# Altium schematic sheet 03_ATK_DLRK3506J_Power_USBHUB.SchDoc
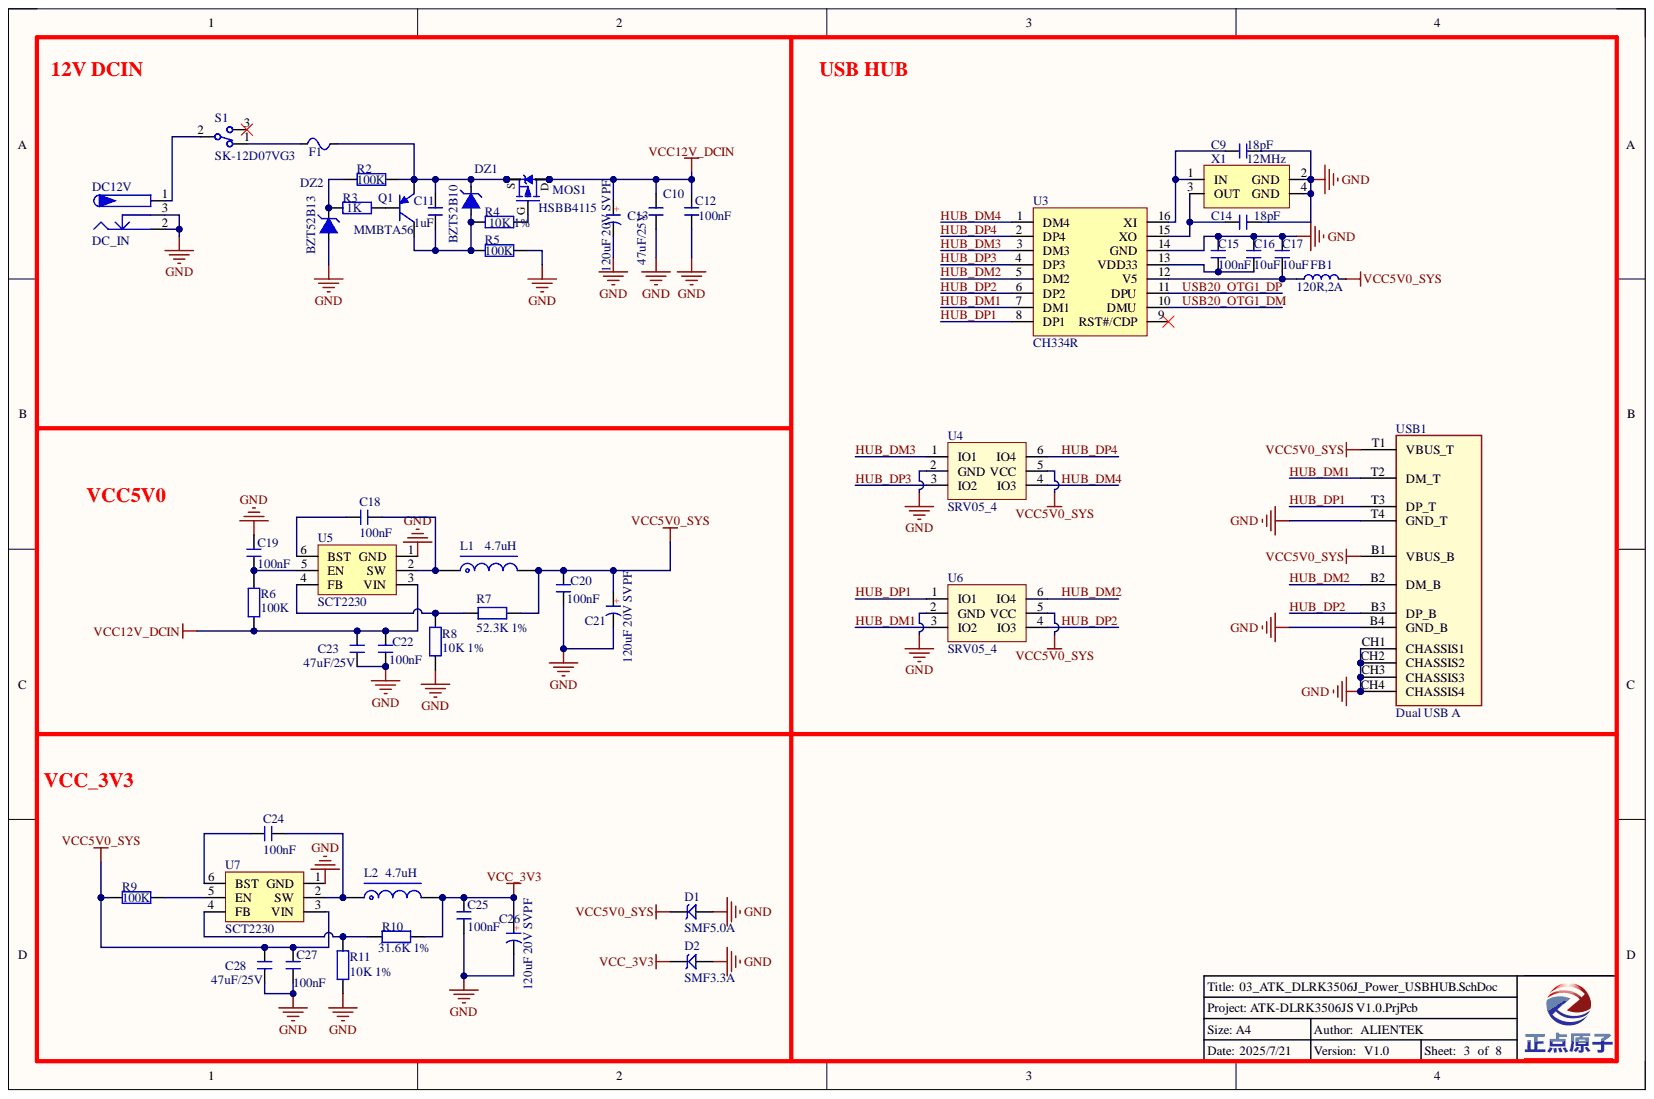
[diagram p0: 03_ATK_DLRK3506J_Power_USBHUB.SchDoc]

## Parts
C9  18pF  [C 0402]
C10  47uF/25V  [C 1206]
C11  1uF  [C 0402]
C12  100nF  [C 0402]
C13  120uF 20V SVPF  [CM D(6.3*7.7)]
C14  18pF  [C 0402]
C15  100nF  [C 0402]
C16  10uF  [C 0402]
C17  10uF  [C 0402]
C18  100nF  [C 0402]
C19  100nF  [C 0402]
C20  100nF  [C 0402]
C21  120uF 20V SVPF  [CM D(6.3*7.7)]
C22  100nF  [C 0402]
C23  47uF/25V  [C 1206]
C24  100nF  [C 0402]
C25  100nF  [C 0402]
C26  120uF 20V SVPF  [CM D(6.3*7.7)]
C27  100nF  [C 0402]
C28  47uF/25V  [C 1206]
D1  SMF5.0A  [SOD-123]
D2  SMF3.3A  [SOD-123]
DC12V  DC_IN  [DC10B]
DZ1  BZT52B10  [SOD-123]
DZ2  BZT52B13  [SOD-123]
F1  2A 保险丝  [FU 1206]  (value not drawn)
FB1  120R,2A  [L 0603]
L1  4.7uH  [L-SMD-0420]
L2  4.7uH  [L-SMD-0420]
MOS1  HSBB4115  [DFN8_ 3x3]
Q1  MMBTA56  [SOT23-3N]
R2  100K  [R 0402]
R3  1K  [R 0402]
R4  10K 1%  [R 0402]
R5  100K  [R 0402]
R6  100K  [R 0402]
R7  52.3K 1%  [R 0402]
R8  10K 1%  [R 0402]
R9  100K  [R 0402]
R10  31.6K 1%  [R 0402]
R11  10K 1%  [R 0402]
S1  SK-12D07VG3  [SW-TH_SK12D07VG3]
U3  CH334R  [QSOP16]
U4  SRV05_4  [SOT23-6N]
U5  SCT2230  [SOT23-6N]
U6  SRV05_4  [SOT23-6N]
U7  SCT2230  [SOT23-6N]
USB1  Dual USB A  [Dual USB TypeA]
X1  12MHz  [XTAL-3225]

## Nets
GND: C9.1 C10.1 C12.2 C13.2 C14.1 C15.1 C16.1 C17.2 C19.2 C20.1 C21.2 C22.1 C23.1 C25.2 C26.2 C27.2 C28.1 D1.1 D2.1 DC12V.2 DC12V.3 DZ2.1 R5.2 R8.1 R11.1 U3.14(GND) U4.2(GND) U5.1(GND) U6.2(GND) U7.1(GND) USB1.B4(GND_B) USB1.CH1(CHASSIS1) USB1.CH2(CHASSIS2) USB1.CH3(CHASSIS3) USB1.CH4(CHASSIS4) USB1.T4(GND_T) X1.2(GND) X1.4(GND)
VCC5V0_SYS: C20.2 C21.1 C27.1 C28.2 D1.2 FB1.2 L1.2 R7.1 R9.1 U4.5(VCC) U6.5(VCC) U7.3(VIN) USB1.B1(VBUS_B) USB1.T1(VBUS_T)
VCC12V_DCIN: C10.2 C12.1 C13.1 C22.2 C23.2 MOS1.5 MOS1.6 MOS1.7 MOS1.8 R6.1 U5.3(VIN)
VCC_3V3: C25.1 C26.1 D2.2 L2.2 R10.2
HUB_DM1: U3.7(DM1) U6.3(IO2) USB1.T2(DM_T)
HUB_DM2: U3.5(DM2) U6.6(IO4) USB1.B2(DM_B)
HUB_DM3: U3.3(DM3) U4.1(IO1)
HUB_DM4: U3.1(DM4) U4.4(IO3)
HUB_DP1: U3.8(DP1) U6.1(IO1) USB1.T3(DP_T)
HUB_DP2: U3.6(DP2) U6.4(IO3) USB1.B3(DP_B)
HUB_DP3: U3.4(DP3) U4.3(IO2)
HUB_DP4: U3.2(DP4) U4.6(IO4)
USB20_OTG1_DM: U3.10(DMU)
USB20_OTG1_DP: U3.11(DPU)
NetC9_2: C9.2 U3.16(XI) X1.1(IN)
NetC11_1: C11.1 DZ1.2 F1.2 MOS1.1 MOS1.2 MOS1.3 Q1.2 R2.2
NetC11_2: C11.2 DZ1.1 Q1.3 R4.1 R5.1
NetC14_2: C14.2 U3.15(XO) X1.3(OUT)
NetC15_2: C15.2 C16.2 U3.13(VDD33)
NetC17_1: C17.1 FB1.1 U3.12(V5)
NetC18_1: C18.1 L1.1 U5.2(SW)
NetC18_2: C18.2 U5.6(BST)
NetC19_1: C19.1 R6.2 U5.5(EN)
NetC24_1: C24.1 U7.6(BST)
NetC24_2: C24.2 L2.1 U7.2(SW)
NetDC12V_1: DC12V.1 S1.2
NetDZ2_2: DZ2.2 R2.1 R3.1
NetF1_1: F1.1 S1.1
NetMOS1_4: MOS1.4 R4.2
NetQ1_1: Q1.1 R3.2
NetR7_2: R7.2 R8.2 U5.4(FB)
NetR9_2: R9.2 U7.5(EN)
NetR10_1: R10.1 R11.2 U7.4(FB)

Not connected: S1 3; U3 9(RST#/CDP)

## Library data of the parts
C9 C14-C17 = C: 无极性电容; BOM名称: 无极性贴片电容; 版权归属: 正点原子; Component Kind: Standard; Library Name: 正点原子封装库V1.0.IntLib; 学习资源: www.openedv.com
C10-C12 C18-C20 C22-C25 C27 C28 = C: 无极性贴片电容; 版权归属: 源创客; BOM名称: 无极性贴片电容; Component Kind: Standard; Library Name: 电阻-电容-电感.SchLib; 学习资源: www.ooocke.com
C13 C21 C26 = C-CM: 贴片电解电容; 版权归属: 源创客; BOM名称: 贴片电解电容; Component Kind: Standard; Library Name: 电阻-电容-电感.SchLib; 学习资源: www.ooocke.com
D1 D2 = SMBJ: TVS; Component Kind: Standard; Library Name: ALIENTEK_COMPONENT.IntLib
DC12V = P-DC: 低压电源接口; BOM名称: DC电源接口; 版权归属: 源创客; Component Kind: Standard; Library Name: 接口类.SchLib; 学习资源: www.ooocke.com
DZ1 DZ2 = BZT52BxVx: CJ x.xV / 0.5W稳压管  2%; BOM名称: 二极管(稳压); 版权归属: 正点原子; Component Kind: Standard; Library Name: 二极管-整流桥.SchLib; 学习资源: www.openedv.com
F1 = FU: 贴片保险丝; 版权归属: 源创客; BOM名称: 贴片保险丝; Component Kind: Standard; Library Name: STM32MP15x底板V1.5.IntLib; 学习资源: www.ooocke.com
FB1 = FB: 贴片磁珠; BOM名称: 小功率贴片电感; Parameter: 电感/磁珠; 版权归属: 正点原子; Component Kind: Standard; Library Name: 正点原子封装库V1.0.IntLib; 学习资源: www.openedv.com
L1 L2 = L-CDRH: 功率屏蔽电感; 版权归属: 源创客; BOM名称: 功率屏蔽电感; Component Kind: Standard; Library Name: 电阻-电容-电感.SchLib; 学习资源: www.ooocke.com
MOS1 = HSBB6115: PMOS -60V -8A@-4.5V 33mΩ; BOM名称: MOS管; 版权归属: 正点原子; Component Kind: Standard; Library Name: 场效应管-可控硅.SchLib; 学习资源: www.openedv.com
Q1 = 9015-SMD: 低噪放大-PNP型; BOM名称: 三极管; 属性: 50V 0.1A 0.4W 150MHZ; 版权归属: 正点原子; Component Kind: Standard; Library Name: 三极管.SchLib; 学习资源: www.ooocke.com
R2-R11 = R: 贴片电阻; BOM名称: 电阻; 版权归属: 正点原子; Component Kind: Standard; Library Name: 电阻-电容-电感.SchLib; 学习资源: www.openedv.com
S1 = SSW-1P: 1路波动开关; BOM名称: 波动开关; 版权归属: 源创客; Component Kind: Standard; Library Name: 按键-开关.SchLib; 学习资源: www.ooocke.com
U3 = CH334R: 4端口USB HUB控制器, USB2.0; Component Kind: Standard; Library Name: ATK-DLIMX93_Library_V1.0.IntLib
U4 U6 = SRV05-4: ESD保护二极管阵列; Component Kind: Standard; Library Name: ATK-DLIMX93_Library_V1.0.IntLib
U5 U7 = DC JW5060T_6: DC降压芯片; Component Kind: Standard; Library Name: LDO和DCDC.SchLib
USB1 = Dual USB TypeA: Component Kind: Standard; Library Name: STM32MP15x底板V1.5.IntLib
X1 = XTAL-4P: 4脚无源晶振; BOM名称: 4脚晶振; Parameter: 晶振; 版权归属: 正点原子; Component Kind: Standard; Library Name: 正点原子封装库V1.0.IntLib; 学习资源: www.openedv.com
